# Altium schematic sheet STM.SchDoc(STM)
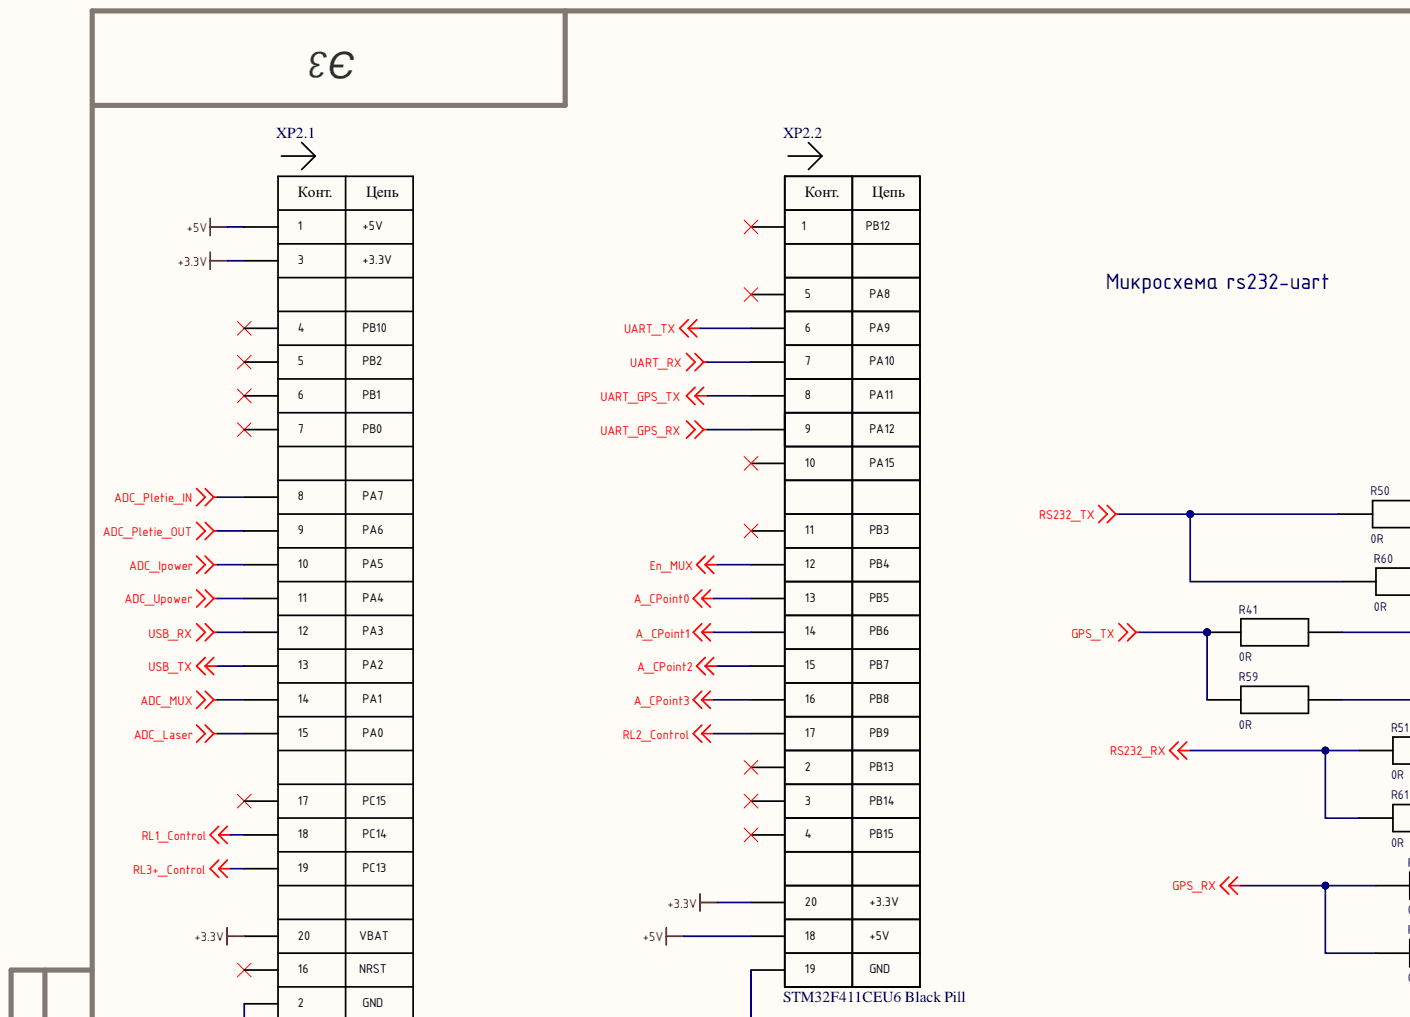
[diagram p0: STM.SchDoc, top left]
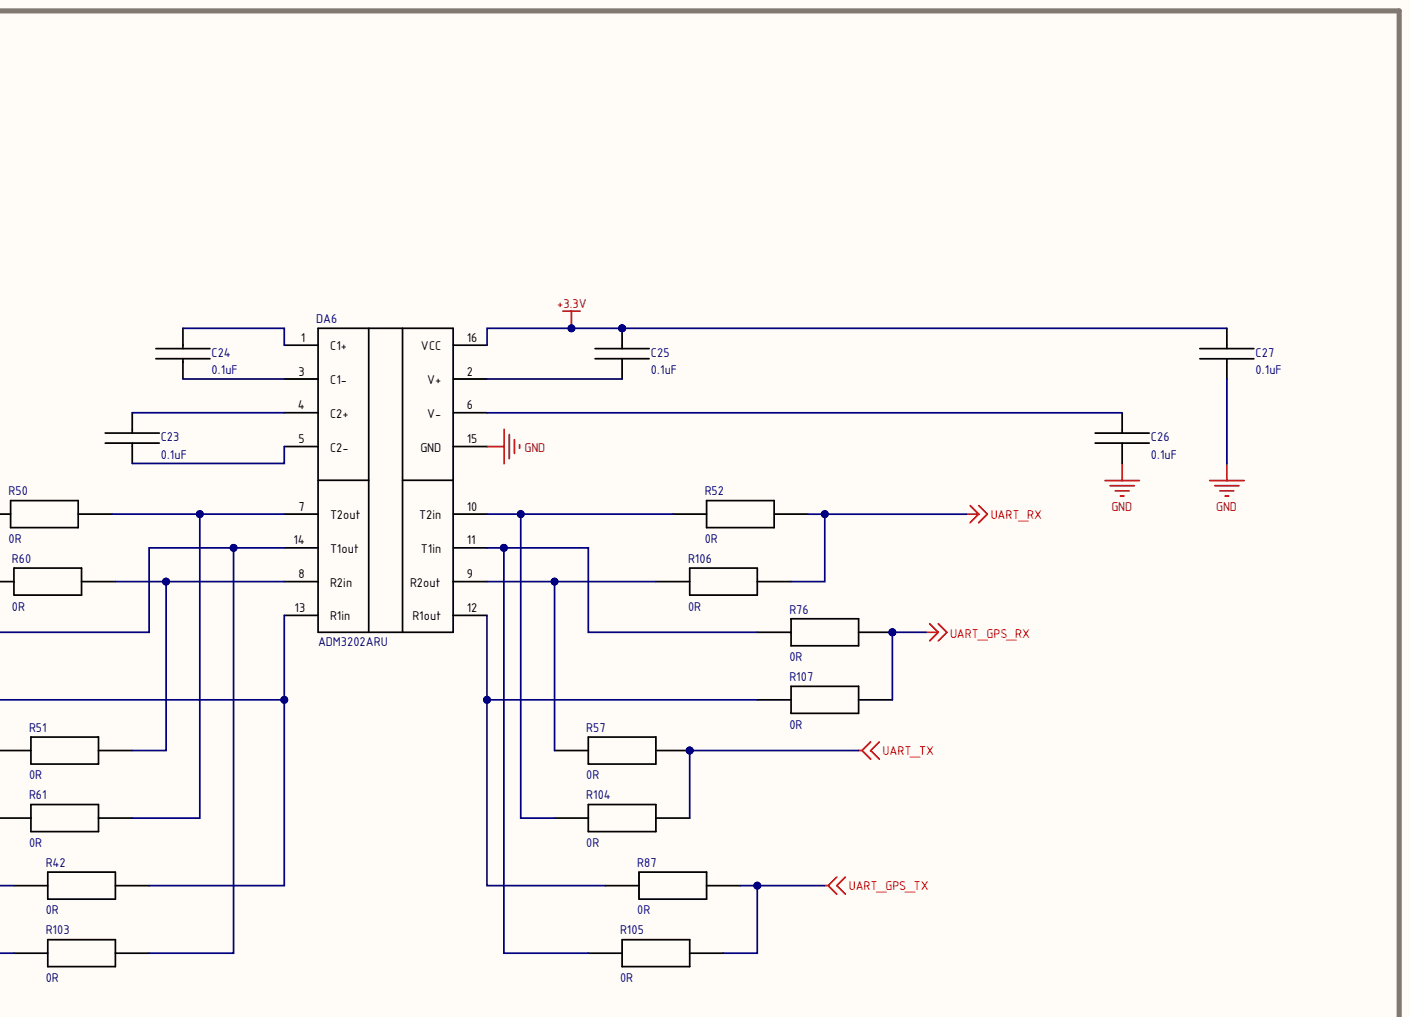
[diagram p1: STM.SchDoc, top right]
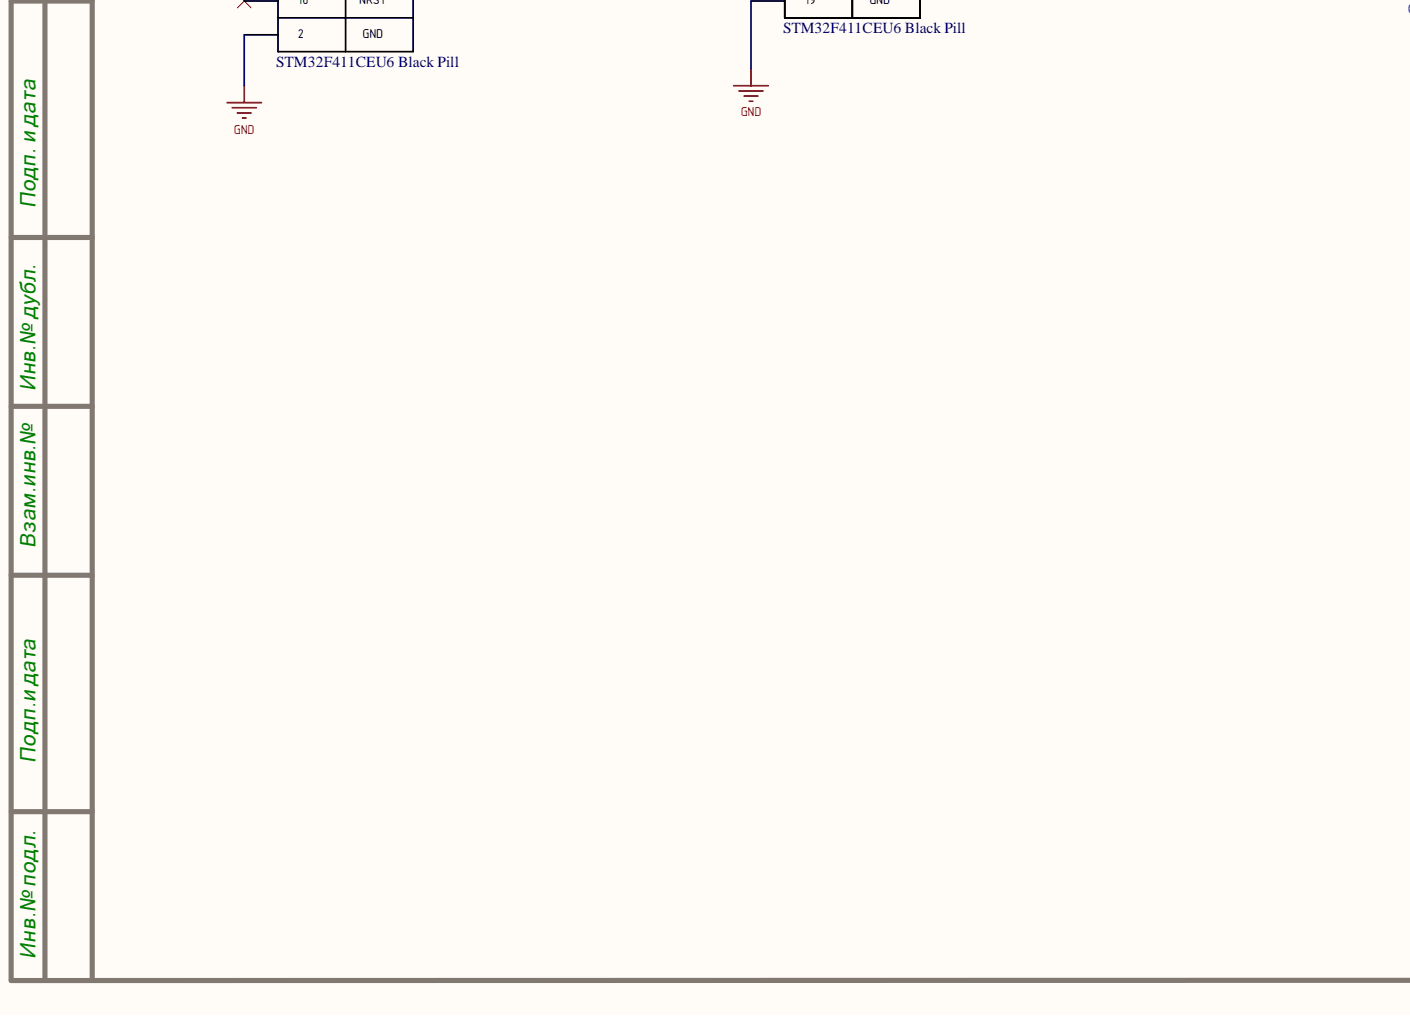
[diagram p2: STM.SchDoc, bottom left]
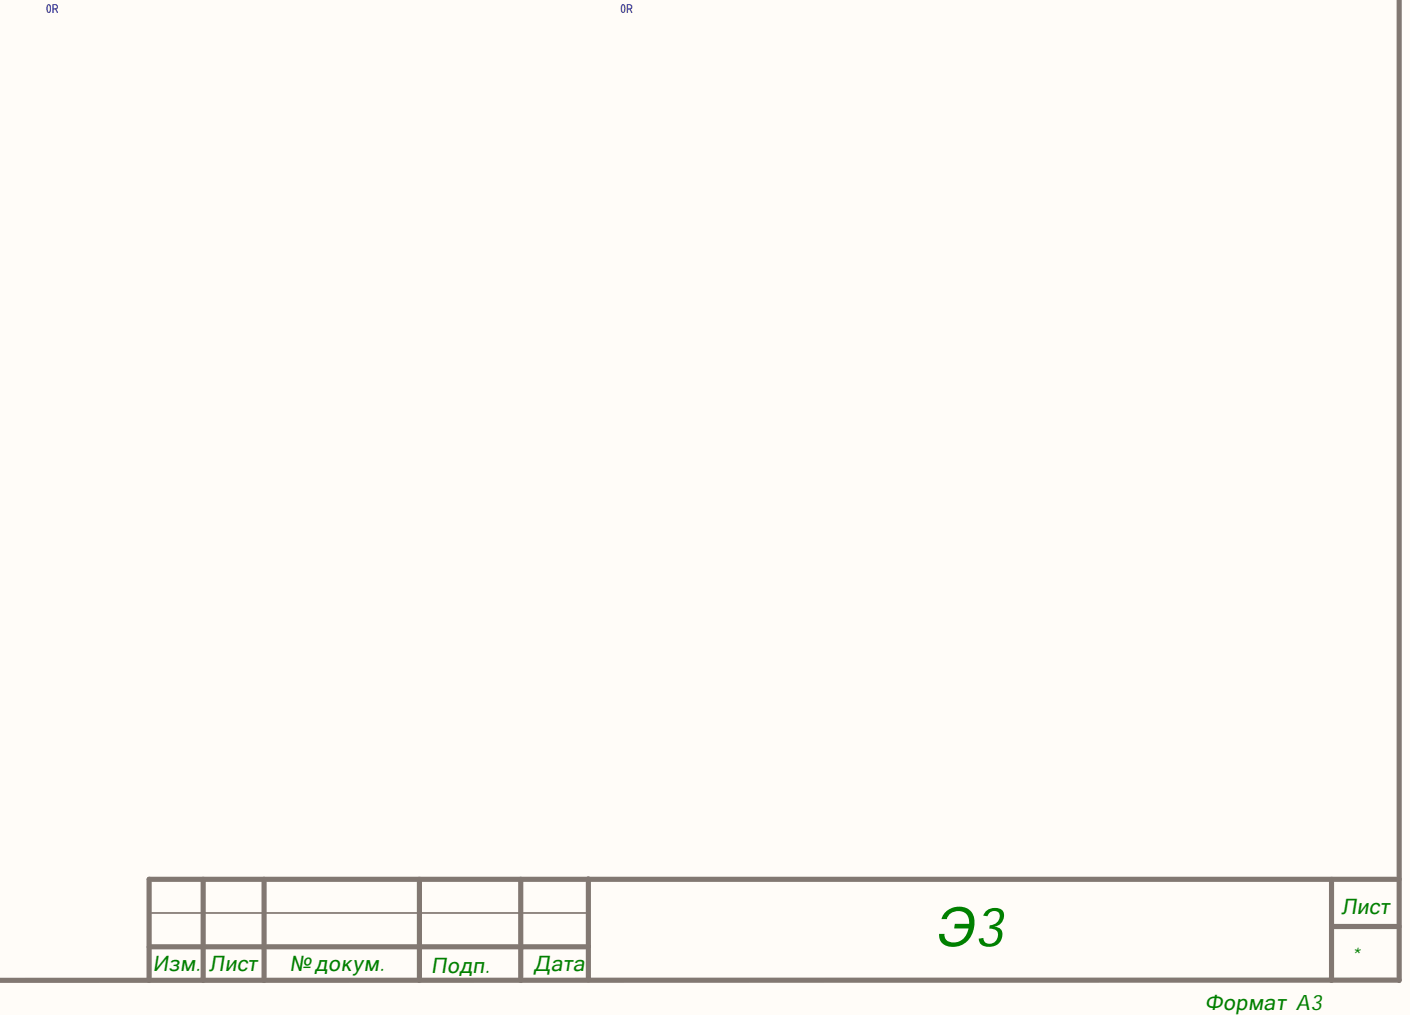
[diagram p3: STM.SchDoc, bottom right]

## Parts
C23  0.1uF  [0603C]
C24  0.1uF  [0603C]
C25  0.1uF  [0603C]
C26  0.1uF  [0603C]
C27  0.1uF  [0603C]
DA6  ADM3202ARU  [TSSOP-16]
R41  0R  [R0402]
R42  0R  [R0402]
R50  0R  [R0402]
R51  0R  [R0402]
R52  0R  [R0402]
R57  0R  [R0402]
R59  0R  [R0402]
R60  0R  [R0402]
R61  0R  [R0402]
R76  0R  [R0402]
R87  0R  [R0402]
R103  0R  [R0402]
R104  0R  [R0402]
R105  0R  [R0402]
R106  0R  [R0402]
R107  0R  [R0402]
XP2  STM32F411CEU6 Black Pill  [Black pill STM32F411CEU6]  (parts .1,.2)

## Nets
+3.3V: C25.2 C27.2 DA6.16(VCC) XP2.3 XP2.20 XP2.40
+5V: XP2.1 XP2.38
A_CPoint0: XP2.33
A_CPoint1: XP2.34
A_CPoint2: XP2.35
A_CPoint3: XP2.36
ADC_Ipower: XP2.10
ADC_Laser: XP2.15
ADC_MUX: XP2.14
ADC_Pletie_IN: XP2.8
ADC_Pletie_OUT: XP2.9
ADC_Upower: XP2.11
En_MUX: XP2.32
GND: C26.1 C27.1 DA6.15(GND) XP2.2 XP2.39
GPS_RX: R42.1 R103.1
GPS_TX: R41.1 R59.1
RL1_Control: XP2.18
RL2_Control: XP2.37
RL3+_Control: XP2.19
RS232_RX: R51.1 R61.1
RS232_TX: R50.1 R60.1
UART_GPS_RX: R76.2 R107.2 XP2.29
UART_GPS_TX: R87.2 R105.2 XP2.28
UART_RX: R52.2 R106.2 XP2.27
UART_TX: R57.2 R104.2 XP2.26
USB_RX: XP2.12
USB_TX: XP2.13
NetC23_1: C23.1 DA6.5(C2-)
NetC23_2: C23.2 DA6.4(C2+)
NetC24_1: C24.1 DA6.3(C1-)
NetC24_2: C24.2 DA6.1(C1+)
NetC25_1: C25.1 DA6.2(V+)
NetC26_2: C26.2 DA6.6(V-)
NetDA6_7: DA6.7(T2out) R50.2 R61.2
NetDA6_8: DA6.8(R2in) R51.2 R60.2
NetDA6_9: DA6.9(R2out) R57.1 R106.1
NetDA6_10: DA6.10(T2in) R52.1 R104.1
NetDA6_11: DA6.11(T1in) R76.1 R105.1
NetDA6_12: DA6.12(R1out) R87.1 R107.1
NetDA6_13: DA6.13(R1in) R42.2 R59.2
NetDA6_14: DA6.14(T1out) R41.2 R103.2

Not connected: XP2 4 5 6 7 16 17 21 22 23 24 25 30 31

## Library data of the parts
C23-C27 = C0603-0.1UF 10% 50V: C0603 0.1UF 10% 50V; Part Number: SMD CAP CER 0.1UF ±10% 50V X7R 0603; Added_by: anton@teslatec.ru; Pkg: 0603; Solder_Amount: 2; Component Kind: Standard; Created_at: 10/01/2023; Mount: MOUNT; MountType: SMD; Library Name: Test_Stand_Pergam.SchLib
DA6 = ADM3202ARU: Low Power, 3.3 V, RS-232  Line Drivers/Receivers; Added_by: irdolgopolowa@yandex.ru; Solder_Amount: 16; Component Kind: Standard; Created_at: 29/10/24; Mount: MOUNT; MountType: SMD; Part Number: ADM3202ARU; Pkg: TSSOP-16; Library Name: Test_Stand_Pergam.SchLib
R41 R42 R50-R52 R57 R59-R61 R76 R87 R103-R107 = R0402-0R: Resistor SMD 0R 1% 0402; Part Number: SMD RES 0R 0402; Added_by: irdolgopolowa@yandex.ru; Pkg: 0402; Solder_Amount: 2; Component Kind: Standard; Created_at: 29/10/24; Mount: MOUNT; MountType: SMD; Library Name: Test_Stand_Pergam.SchLib
XP2 = STM32F411CEU6 Black pil: Mating Part: 2*DS1021-1*20SF11-B; Added_by: irdolgopolowa@yandex.ru; Solder_Amount: 20; Component Kind: Standard; Created_at: 29/10/24; Description: STM32F411CEU6 Black pil; Mount: MOUNT; MountType: THM; Part Number: STM32F411CEU6 Black Pill; Pkg: Black pil; Library Name: Test_Stand_Pergam.SchLib
